# Altium schematic sheet TruckCape BeagleBone Headers v2.SchDoc
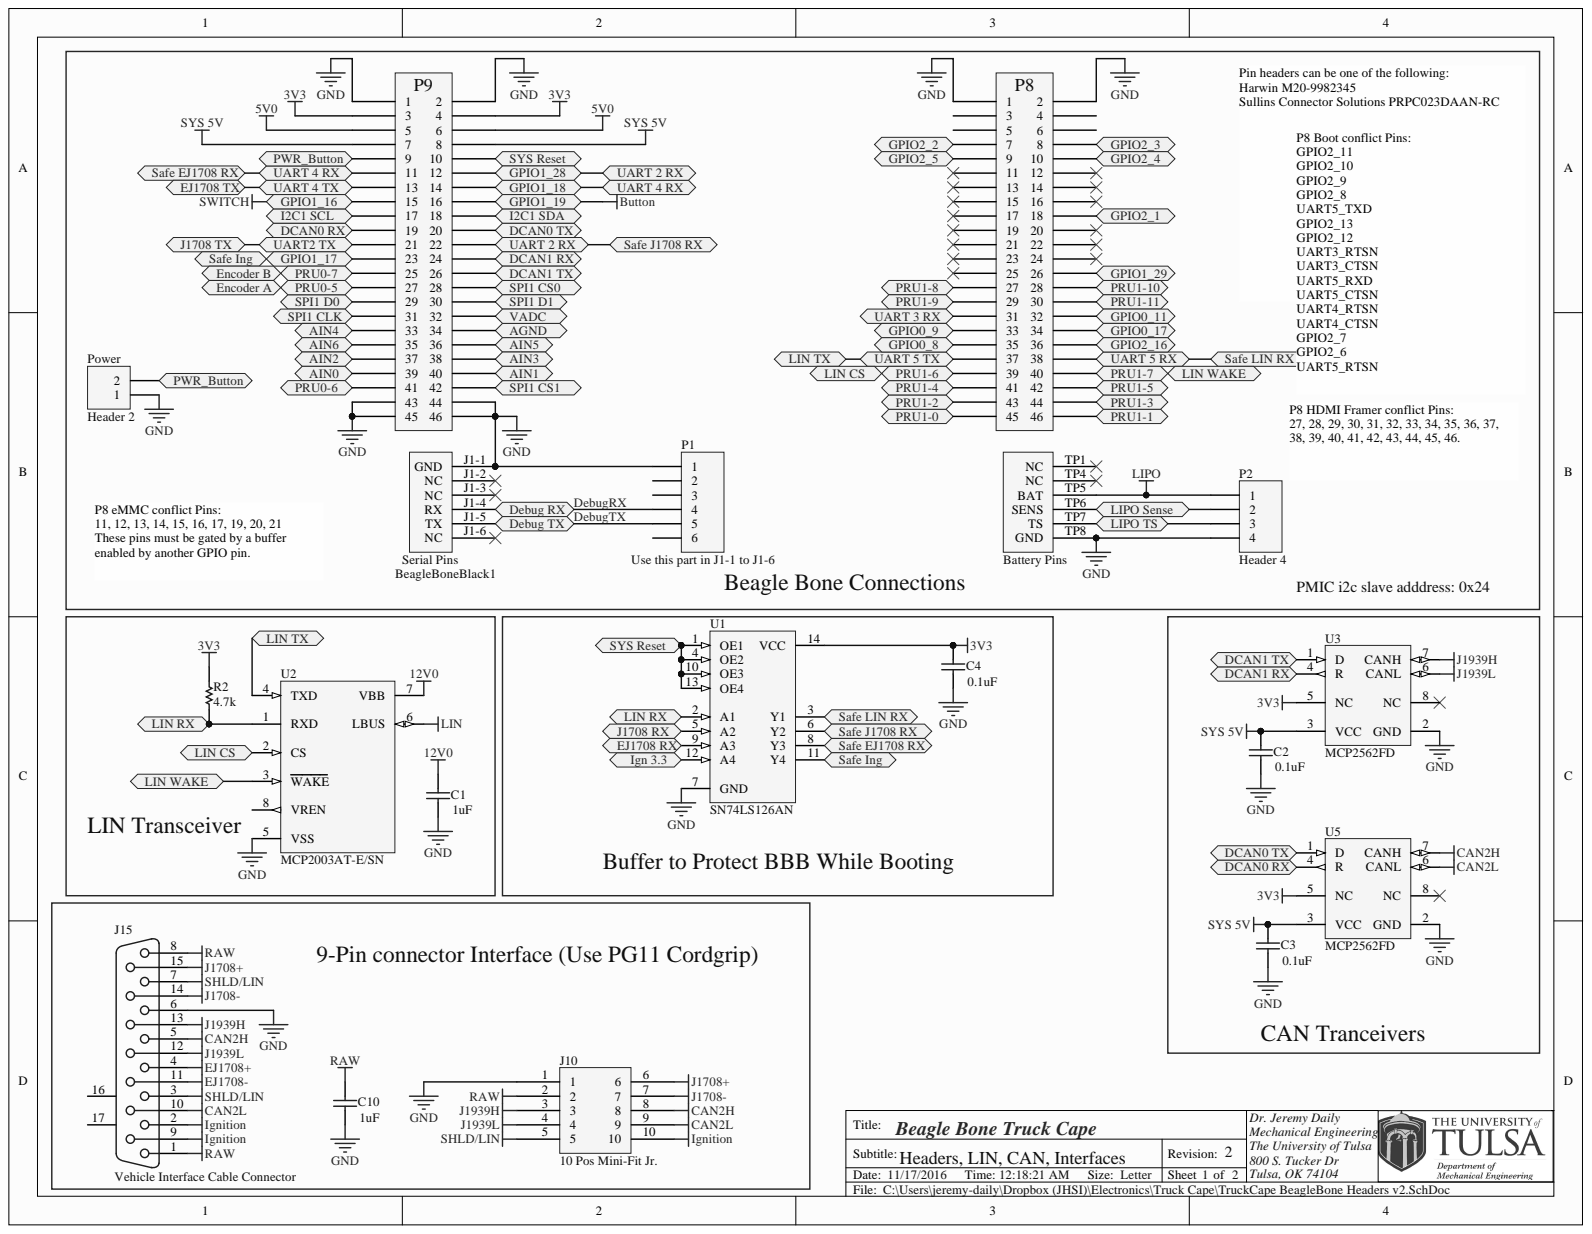
[diagram p0: TruckCape BeagleBone Headers v2.SchDoc]

## Parts
BeagleBoneBlack1  BeagleBoneBlack  [Beagleboneblack]
C1  1uF  [CAPR5-4X5]
C2  0.1uF  [CAPR5-4X5]
C3  0.1uF  [CAPR5-4X5]
C4  0.1uF  [CAPR5-4X5]
C10  1uF  [CAPR5-4X5]
J10  10 Pos Mini-Fit Jr.  [10 pos Mini-Fit Jr. R/A Header]
J15  Vehicle Interface Cable Connector  [DB15]
P1  Use this part in J1-1 to J1-6  [HDR1X6]
P2  Header 4  [HDR1X4]
Power  Header 2  [HDR1X2]
R2  4.7k  [Axial-0.4]
U1  SN74LS126AN  [N14A]
U2  MCP2003AT-E/SN  [PDIP300-P8]
U3  MCP2562FD  [SN65HVD232]
U5  MCP2562FD  [SN65HVD232]

## Ports
AGND, AIN0, AIN1, AIN2, AIN3, AIN4, AIN5, AIN6, DCAN0 RX, DCAN0 TX, DCAN1 RX, DCAN1 TX, DEBUG RX, DEBUG TX, EJ1708 RX, EJ1708 TX, ENCODER A, ENCODER B, GPIO0_8, GPIO0_9, GPIO0_11, GPIO0_17, GPIO1_16, GPIO1_17, GPIO1_18, GPIO1_19, GPIO1_28, GPIO1_29, GPIO2_1, GPIO2_2, GPIO2_3, GPIO2_4, GPIO2_5, GPIO2_16, I2C1 SCL, I2C1 SDA, IGN 3.3, J1708 RX, J1708 TX, LIN CS, LIN RX, LIN TX, LIN WAKE, LIPO SENSE, LIPO TS, PRU0-5, PRU0-6, PRU0-7, PRU1-0, PRU1-1, PRU1-2, PRU1-3, PRU1-4, PRU1-5, PRU1-6, PRU1-7, PRU1-8, PRU1-9, PRU1-10, PRU1-11, PWR_BUTTON, SAFE EJ1708 RX, SAFE ING, SAFE J1708 RX, SAFE LIN RX, SPI1 CLK, SPI1 CS0, SPI1 CS1, SPI1 D0, SPI1 D1, SYS RESET, UART2 TX, UART 2 RX, UART 3 RX, UART 4 RX, UART 4 TX, UART 5 RX, UART 5 TX, VADC

## Nets
3V3: BeagleBoneBlack1.3 BeagleBoneBlack1.4 C4.1 R2.1 U1.14(VCC) U3.5(NC) U5.5(NC)
5V0: BeagleBoneBlack1.5 BeagleBoneBlack1.6
12V0: C1.1 U2.7(VBB)
CAN2H: J10.8 J15.5 U5.7(CANH)
CAN2L: J10.9 J15.10 U5.6(CANL)
EJ1708+: J15.4
EJ1708-: J15.11
GND: BeagleBoneBlack1.1 BeagleBoneBlack1.2 BeagleBoneBlack1.43 BeagleBoneBlack1.44 BeagleBoneBlack1.45 BeagleBoneBlack1.46 BeagleBoneBlack1.J1-1 BeagleBoneBlack1.P1 BeagleBoneBlack1.P2 BeagleBoneBlack1.TP8(GND) C1.2 C2.2 C3.2 C4.2 C10.2 J10.1 J15.6 P1.1 P2.4 Power.1 U1.7(GND) U2.5(VSS) U3.2(GND) U5.2(GND)
IGNITION: J10.10 J15.2 J15.9
J1708+: J10.6 J15.15
J1708-: J10.7 J15.14
J1939H: J10.3 J15.13 U3.7(CANH)
J1939L: J10.4 J15.12 U3.6(CANL)
LIN: U2.6(LBUS)
LIPO: BeagleBoneBlack1.TP5(BAT) P2.1
RAW: C10.1 J10.2 J15.1 J15.8
SHLD/LIN: J10.5 J15.3 J15.7
SYS 5V: BeagleBoneBlack1.7 BeagleBoneBlack1.8 C2.1 C3.1 U3.3(VCC) U5.3(VCC)
AGND: BeagleBoneBlack1.34(AGND) ; port AGND
AIN0: BeagleBoneBlack1.39 ; port AIN0
AIN1: BeagleBoneBlack1.40(AIN1) ; port AIN1
AIN2: BeagleBoneBlack1.37 ; port AIN2
AIN3: BeagleBoneBlack1.38(AIN3) ; port AIN3
AIN4: BeagleBoneBlack1.33 ; port AIN4
AIN5: BeagleBoneBlack1.36(AIN5) ; port AIN5
AIN6: BeagleBoneBlack1.35 ; port AIN6
BUTTON: BeagleBoneBlack1.16(GPIO1_19) ; port GPIO1_19
DCAN0 RX: BeagleBoneBlack1.19 U5.4(R) ; port DCAN0 RX
DCAN0 TX: BeagleBoneBlack1.20(DCAN0_TX) U5.1(D) ; port DCAN0 TX
DCAN1 RX: BeagleBoneBlack1.24(DCAN1_RX) U3.4(R) ; port DCAN1 RX
DCAN1 TX: BeagleBoneBlack1.26(DCAN1_TX) U3.1(D) ; port DCAN1 TX
DEBUGRX: BeagleBoneBlack1.J1-4(Debug_RX) P1.4 ; port DEBUG RX
DEBUGTX: BeagleBoneBlack1.J1-5(Debug_TX) P1.5 ; port DEBUG TX
EJ1708 RX: U1.9(A3) ; port EJ1708 RX
EJ1708 TX: BeagleBoneBlack1.13 ; port EJ1708 TX, port UART 4 TX
GPIO0_8: BeagleBoneBlack1.P35(GPIO0_8) ; port GPIO0_8
GPIO0_9: BeagleBoneBlack1.P33(GPIO0_9) ; port GPIO0_9
GPIO0_11: BeagleBoneBlack1.P32 ; port GPIO0_11
GPIO0_17: BeagleBoneBlack1.P34 ; port GPIO0_17
GPIO1_17: BeagleBoneBlack1.23 U1.11(Y4) ; port GPIO1_17
GPIO1_18: BeagleBoneBlack1.11 BeagleBoneBlack1.14(GPIO1_18) U1.8(Y3) ; port GPIO1_18, port UART 4 RX
GPIO1_28: BeagleBoneBlack1.12(GPIO1_28) BeagleBoneBlack1.22(UART_2_RX) U1.6(Y2) ; port GPIO1_28, port UART 2 RX
GPIO1_29: BeagleBoneBlack1.P26 ; port GPIO1_29
GPIO2_1: BeagleBoneBlack1.P18 ; port GPIO2_1
GPIO2_2: BeagleBoneBlack1.P7(GPIO2_2) ; port GPIO2_2
GPIO2_3: BeagleBoneBlack1.P8 ; port GPIO2_3
GPIO2_4: BeagleBoneBlack1.P10 ; port GPIO2_4
GPIO2_5: BeagleBoneBlack1.P9(GPIO2_5) ; port GPIO2_5
GPIO2_16: BeagleBoneBlack1.P36 ; port GPIO2_16
I2C1 SCL: BeagleBoneBlack1.17 ; port I2C1 SCL
I2C1 SDA: BeagleBoneBlack1.18(I2C1_SDA) ; port I2C1 SDA
J1708 RX: U1.5(A2) ; port J1708 RX
J1708 TX: BeagleBoneBlack1.21 ; port J1708 TX, port UART2 TX
LIN CS: BeagleBoneBlack1.P39(PRU1-6) U2.2(CS) ; port LIN CS, port PRU1-6
LIN RX: R2.2 U1.2(A1) U2.1(RXD) ; port LIN RX
LIN TX: BeagleBoneBlack1.P37(UART_5_TX) U2.4(TXD) ; port LIN TX, port UART 5 TX
LIN WAKE: BeagleBoneBlack1.P40 U2.3(WAKE) ; port LIN WAKE, port PRU1-7
LIPO TS: BeagleBoneBlack1.TP7(TS) P2.3 ; port LIPO TS
PRU0-5: BeagleBoneBlack1.27 ; port PRU0-5
PRU0-6: BeagleBoneBlack1.41 ; port PRU0-6
PRU0-7: BeagleBoneBlack1.25 ; port PRU0-7
PRU1-0: BeagleBoneBlack1.P45(PRU1-0) ; port PRU1-0
PRU1-1: BeagleBoneBlack1.P46 ; port PRU1-1
PRU1-2: BeagleBoneBlack1.P43(PRU1-2) ; port PRU1-2
PRU1-3: BeagleBoneBlack1.P44 ; port PRU1-3
PRU1-4: BeagleBoneBlack1.P41(PRU1-4) ; port PRU1-4
PRU1-5: BeagleBoneBlack1.P42 ; port PRU1-5
PRU1-8: BeagleBoneBlack1.P27(PRU1-8) ; port PRU1-8
PRU1-9: BeagleBoneBlack1.P29(PRU1-9) ; port PRU1-9
PRU1-10: BeagleBoneBlack1.P28 ; port PRU1-10
PRU1-11: BeagleBoneBlack1.P30 ; port PRU1-11
SPI1 CLK: BeagleBoneBlack1.31 ; port SPI1 CLK
SPI1 CS0: BeagleBoneBlack1.28(SPI1_CS0) ; port SPI1 CS0
SPI1 CS1: BeagleBoneBlack1.42(SPI1_CS1) ; port SPI1 CS1
SPI1 D0: BeagleBoneBlack1.29 ; port SPI1 D0
SPI1 D1: BeagleBoneBlack1.30(SPI1_D1) ; port SPI1 D1
SWITCH: BeagleBoneBlack1.15 ; port GPIO1_16
UART 3 RX: BeagleBoneBlack1.P31(UART_3_RX) ; port UART 3 RX
UART 5 RX: BeagleBoneBlack1.P38 U1.3(Y1) ; port UART 5 RX
VADC: BeagleBoneBlack1.32(VADC) ; port VADC
NetBeagleBoneBlack1_9: BeagleBoneBlack1.9 Power.2 ; port PWR_BUTTON
NetBeagleBoneBlack1_10: BeagleBoneBlack1.10(SYS_Reset) U1.1(OE1) U1.4(OE2) U1.10(OE3) U1.13(OE4) ; port SYS RESET
NetBeagleBoneBlack1_TP6: BeagleBoneBlack1.TP6(SENS) P2.2 ; port LIPO SENSE
NetU1_12: U1.12(A4) ; port IGN 3.3

Not connected: BeagleBoneBlack1 J1-2 J1-3 J1-6 P3 P4 P5 P6 P11 P12 P13 P14 P15 P16 P17 P19 P20 P21 P22 P23 P24 P25 TP1(NC) TP4(NC); J15 16 17; P1 2 3 6; U2 8(VREN); U3 8(NC); U5 8(NC)

## Library data of the parts
BeagleBoneBlack1 = BeagleBoneBlack: 4 pin header for Revision C with all headers and test points; Manufacturer: 3M; PartNumber: 929836-01-02-RK; Component Kind: Standard; Library Name: BeagleBoneBlack.IntLib; Supplier 1: Digi-Key; Supplier Part Number 1: 929836E-01-02-ND
C1 C10 = Cap: CAP CER 1UF 25V 10% X5R 0603; Manufacturer: Murata Electronics North America; PartNumber: GRM188R61E105KA12D; Component Kind: Standard; Library Name: SSS Schematic Library.SchLib; Signal Integrity: Cap; Simulation: CAP; Supplier 1: Digi-Key; Supplier Part Number 1: 490-3897-1-ND
C2-C4 = Cap: CAP CER 0.1UF 50V 10% X7R 0603; Manufacturer: Murata Electronics North America; PartNumber: GRM188R71H104KA93D; Component Kind: Standard; Library Name: SSS Schematic Library.SchLib; Signal Integrity: Cap; Simulation: CAP; Supplier 1: Digi-Key; Supplier Part Number 1: 490-1519-1-ND
J10 = 10 Pos Header: Manufacturer: Molex; Component Kind: Standard; Library Name: Molex Mini-Fit Jr.IntLib; PartNumber: 39-30-1100; Supplier 1: Digi-Key; Supplier Part Number 1: WM1355-ND
J15 = 788781-2: Receptacle Assembly, 15 Position, Right Angle, 8.08mm Series, Amplimite, with Screwlocks; Manufacturer: FCI; PartNumber: D15S33E4GX00LF; Component Kind: Standard; Library Name: SSS Schematic Library.SchLib; Signal Integrity: D Subminiature; Supplier 1: Mouser; Supplier Part Number 1: 649-D15S33E4GX00LF
P1 = Header 6: CONN HEADER FEMALE 6POS .1" GOLD; Manufacturer: Sullins Connector Solutions; PartNumber: PPPC061LFBN-RC; Component Kind: Standard; Library Name: Miscellaneous Connectors.IntLib; Signal Integrity: Connector; Supplier 1: Digi-Key; Supplier Part Number 1: S7039-ND
P2 = Header 4: Header, 4-Pin; Component Kind: Standard; LatestRevisionDate: 17-Jul-2002; LatestRevisionNote: Re-released for DXP Platform.; Library Name: Miscellaneous Connectors.IntLib; Signal Integrity: Connector; Publisher: Altium Limited
Power = Header 2: Header, 2-Pin; Component Kind: Standard; LatestRevisionDate: 17-Jul-2002; LatestRevisionNote: Re-released for DXP Platform.; Library Name: Miscellaneous Connectors.IntLib; Signal Integrity: Connector; Publisher: Altium Limited
R2 = Chip Resistor: RES SMD 4.7K OHM 1% 1/10W 0603; Manufacturer: Panasonic Electronic Components; PartNumber: ERJ-3EKF4701V; Component Kind: Standard; Library Name: SSS Schematic Library.SchLib; Supplier 1: Digi-Key; Supplier Part Number 1: P4.70KHCT-ND
U1 = DM74LS126AN: Quad 3-State Buffer; Manufacturer: Texas Instruments; Component Kind: Standard; ComponentLink1Description: Manufacturer Link; ComponentLink1URL: http://www.fairchildsemi.com/; DatasheetVersion: Mar-1998; LatestRevisionDate: 29-May-2009; LatestRevisionNote: Component Body Added.; Library Name: FSC Logic Buffer Line Driver.IntLib; PackageDescription: DIP, 14-Pin, Row Spacing 7.62 mm, Pitch 2.54 mm; PackageReference: N14A; PackageVersion: 2000; PartNumber: SN74LS126AN; PCB3D: N14A; Published: 17-Jul-2002; Signal Integrity: DM74LS126AN; Simulation: 74LS126X4; Supplier 1: Digi-Key; Supplier Part Number 1: 296-3643-5-ND; Publisher: Altium Limited
U2 = MCP2003AT-E/SN: LIN J2602 Transceiver, 8-Pin SOIC, Extended Temperature, Tape and Reel; Manufacturer: Microchip; PartNumber: MCP2003-E/P; Component Kind: Standard; Library Name: Microchip Interface.IntLib; Supplier 1: Digi-Key; Supplier Part Number 1: MCP2003-E/P-ND
U3 U5 = SN65HVD232DR: 3.3 V Can Transceiver, 17 mA, -40 to 85 degC, 8-pin SOIC (D), Green (RoHS & no Sb/Br); Manufacturer: Texas Instruments; Drivers Per Package: 1; ICC(Max)(mA): 17; Rating: Catalog; Supply Voltage(s)(V): 3.3; Transient Pulse Protection(V): -25 to 25; Code_JEDEC: MS-012AA; Component Kind: Standard; ComponentLink1Description: Datasheet; ComponentLink1URL: http://www.ti.com/general/docs/lit/getliterature.tsp?genericPartNumber=SN65HVD232&fileType=pdf; DatasheetVersion: SLOS346K; Eco-Plan: Green (RoHS & no Sb/Br); Isolated: No; Library Name: Texas Instruments Interface CAN.IntLib; Mounting Technology: Surface Mount; PackageDescription: 8-Pin Plastic Small Outline Integrated Circuit, 4.9 x 3.9 mm, Pitch 1.27 mm Pitch; PackageReference: D8; PackageVersion: revM, Jun-2011; PartNumber: SN65HVD232DR; Receivers Per Package: 1; SubFamily: CAN; Supplier 1: Digi-Key; Supplier 2: Digi-Key; Supplier 3: Digi-Key; Supplier 4: Newark; Supplier 5: Mouser; Supplier Part Number 1: 296-26344-2-ND; Supplier Part Number 2: 296-26344-1-ND; Supplier Part Number 3: 296-26344-6-ND; Supplier Part Number 4: 75C7753; Supplier Part Number 5: 595-SN65HVD232DR
